# Altium schematic sheet Drone BLDC CNTR V2.SchDoc
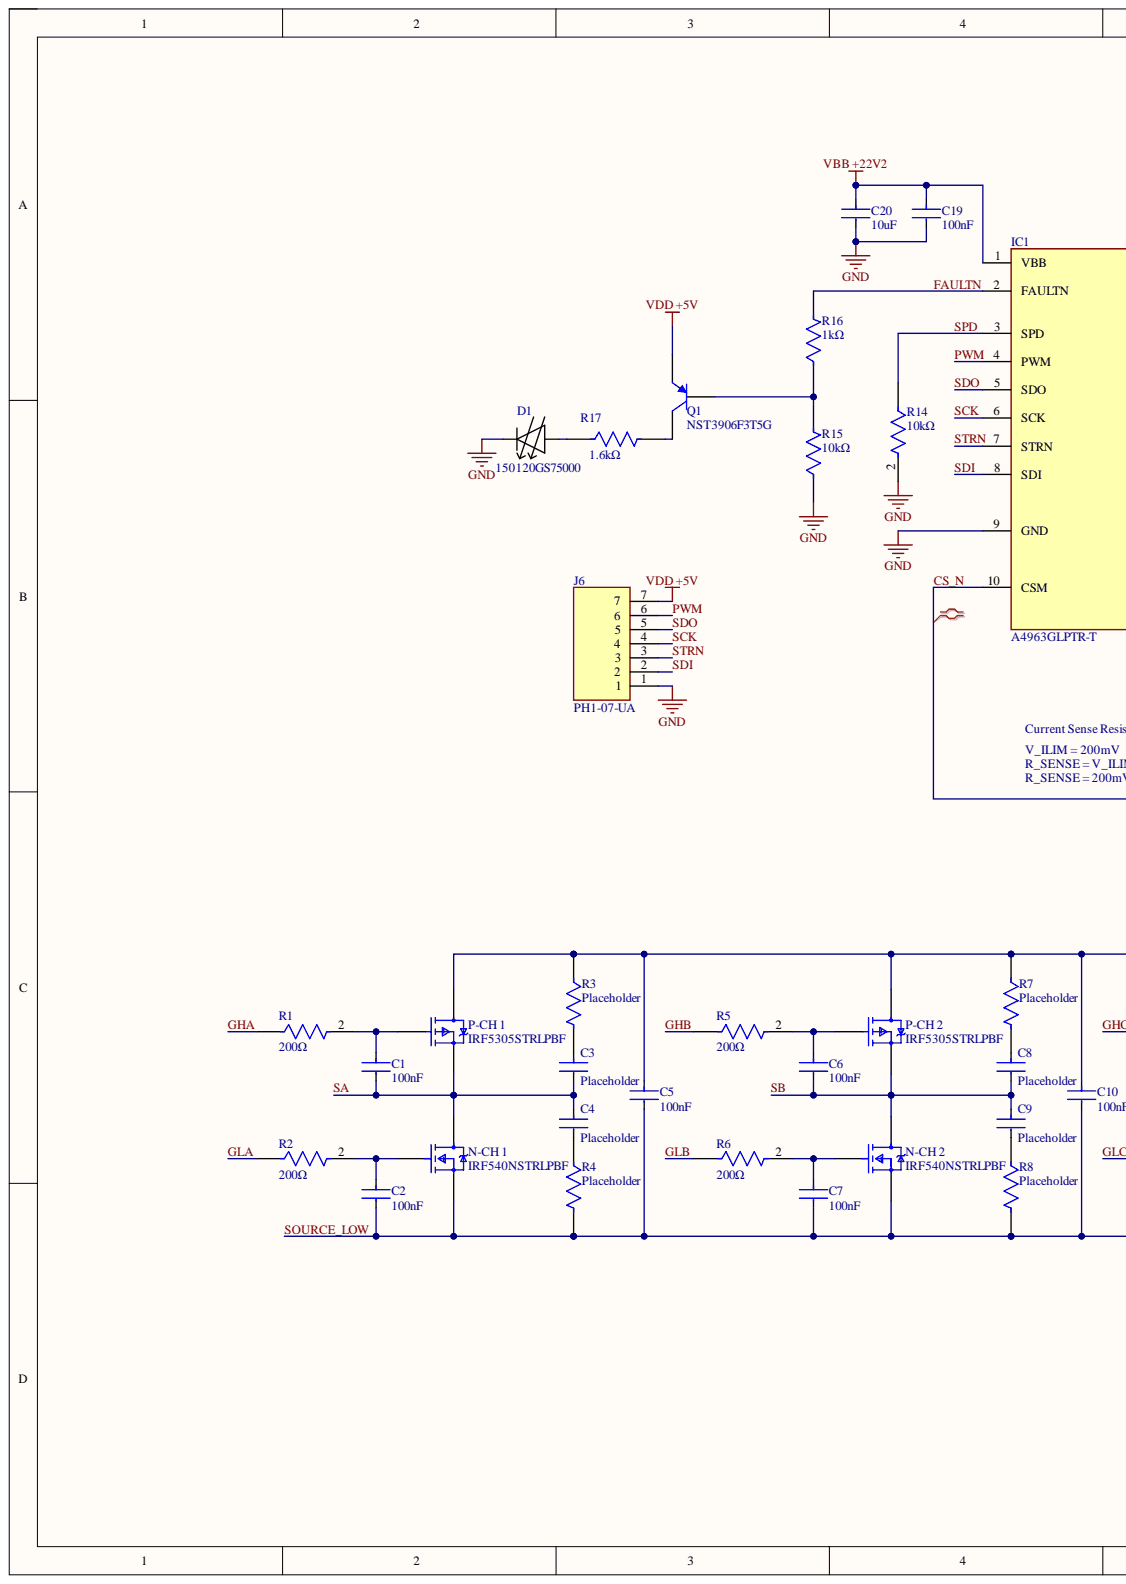
[diagram p0: Drone BLDC CNTR V2.SchDoc, left]
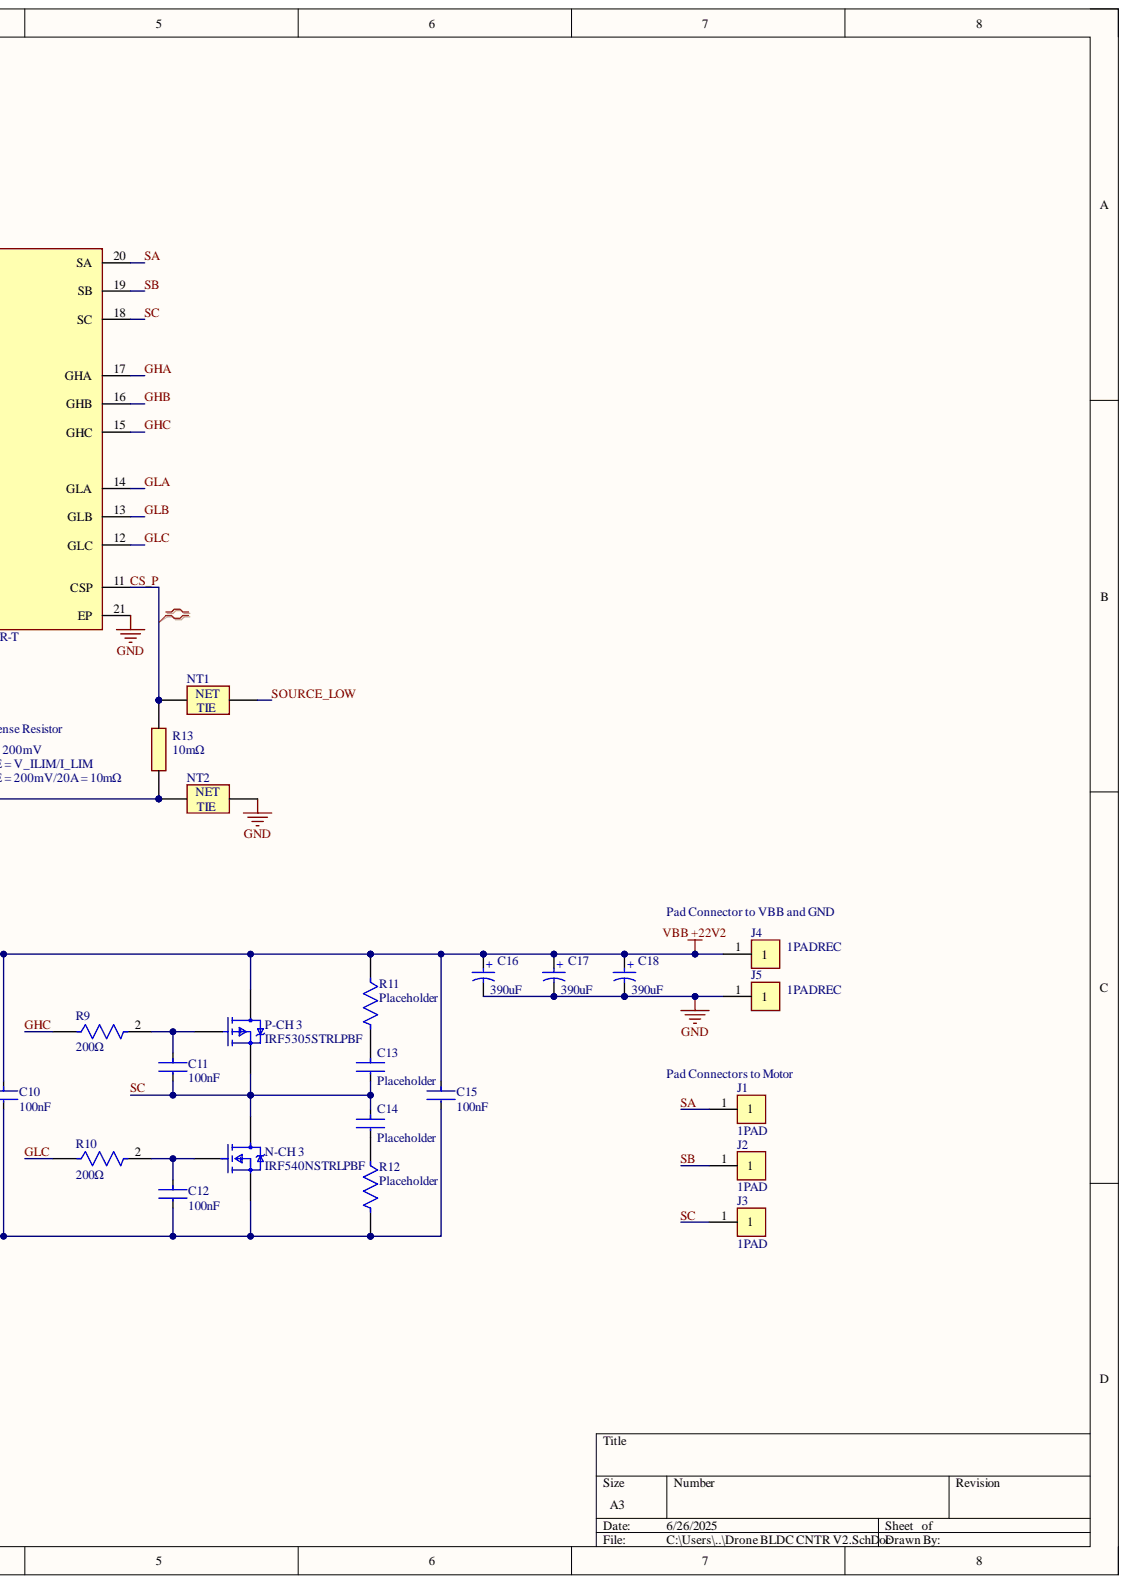
[diagram p1: Drone BLDC CNTR V2.SchDoc, right]

## Parts
C1  100nF  [FP-CL31-IPC_C]
C2  100nF  [FP-CL31-IPC_C]
C3  Placeholder  [FP-CL31-IPC_C]
C4  Placeholder  [FP-CL31-IPC_C]
C5  100nF  [FP-CL31-IPC_C]
C6  100nF  [FP-CL31-IPC_C]
C7  100nF  [FP-CL31-IPC_C]
C8  Placeholder  [FP-CL31-IPC_C]
C9  Placeholder  [FP-CL31-IPC_C]
C10  100nF  [FP-CL31-IPC_C]
C11  100nF  [FP-CL31-IPC_C]
C12  100nF  [FP-CL31-IPC_C]
C13  Placeholder  [FP-CL31-IPC_C]
C14  Placeholder  [FP-CL31-IPC_C]
C15  100nF  [FP-CL31-IPC_C]
C16  390uF  [WCAP-ASLI_D6.3H5.5]
C17  390uF  [WCAP-ASLI_D6.3H5.5]
C18  390uF  [WCAP-ASLI_D6.3H5.5]
C19  100nF  [FP-CL31-IPC_C]
C20  10uF  [FP-CL10-IPC_C]
D1  150120GS75000  [150120GS75000]
IC1  A4963GLPTR-T  [A4405KLPTRT]
J1  1PAD  [1PAD]
J2  1PAD  [1PAD]
J3  1PAD  [1PAD]
J4  1PADREC  [1PADREC]
J5  1PADREC  [1PADREC]
J6  PH1-07-UA  [HDRV7W64P0X254_1X7_1778X250X850P]
N-CH_1  IRF540NSTRLPBF  [FP-PG-TO263-3-MFG]
N-CH_2  IRF540NSTRLPBF  [FP-PG-TO263-3-MFG]
N-CH_3  IRF540NSTRLPBF  [FP-PG-TO263-3-MFG]
NT1  NET_TIE  [NET_TIE]  (value not drawn)
NT2  NET_TIE  [NET_TIE]  (value not drawn)
P-CH_1  IRF5305STRLPBF  [FP-PG-TO263-3-MFG]
P-CH_2  IRF5305STRLPBF  [FP-PG-TO263-3-MFG]
P-CH_3  IRF5305STRLPBF  [FP-PG-TO263-3-MFG]
Q1  NST3906F3T5G  [ONSC-SOT-1123-3-524AA_V]
R1  200Ω  [FP-RMCF0805-MFG]
R2  200Ω  [FP-RMCF0805-MFG]
R3  Placeholder  [FP-RMCF0805-MFG]
R4  Placeholder  [FP-RMCF0805-MFG]
R5  200Ω  [FP-RMCF0805-MFG]
R6  200Ω  [FP-RMCF0805-MFG]
R7  Placeholder  [FP-RMCF0805-MFG]
R8  Placeholder  [FP-RMCF0805-MFG]
R9  200Ω  [FP-RMCF0805-MFG]
R10  200Ω  [FP-RMCF0805-MFG]
R11  Placeholder  [FP-RMCF0805-MFG]
R12  Placeholder  [FP-RMCF0805-MFG]
R13  10mΩ  [RESC7250X190N]
R14  10kΩ  [FP-RMCF0805-MFG]
R15  10kΩ  [FP-RMCF0805-MFG]
R16  1kΩ  [FP-RMCF0805-IPC_C]
R17  1.6kΩ  [FP-RMCF0805-IPC_C]

## Nets
GND: C16.2 C17.2 C18.2 C19.2 C20.2 D1.1 IC1.9(GND) IC1.21(EP) J5.1 J6.1 NT2.1 R14.2 R15.1
VBB +22V2: C5.1 C10.1 C15.1 C16.1 C17.1 C18.1 C19.1 C20.1 IC1.1(VBB) J4.1 P-CH_1.4 P-CH_2.4 P-CH_3.4 R3.1 R7.1 R11.1
VDD +5V: J6.7 Q1.2
CS_N: IC1.10(CSM) NT2.2 R13.1
CS_P: IC1.11(CSP) NT1.2 R13.2
FAULTN: IC1.2(FAULTN) R16.2
GHA: IC1.17(GHA) R1.1
GHB: IC1.16(GHB) R5.1
GHC: IC1.15(GHC) R9.1
GLA: IC1.14(GLA) R2.1
GLB: IC1.13(GLB) R6.1
GLC: IC1.12(GLC) R10.1
PWM: IC1.4(PWM) J6.6
SA: C1.2 C3.2 C4.1 IC1.20(SA) J1.1 N-CH_1.4 P-CH_1.3
SB: C6.2 C8.2 C9.1 IC1.19(SB) J2.1 N-CH_2.4 P-CH_2.3
SC: C11.2 C13.2 C14.1 IC1.18(SC) J3.1 N-CH_3.4 P-CH_3.3
SCK: IC1.6(SCK) J6.4
SDI: IC1.8(SDI) J6.2
SDO: IC1.5(SDO) J6.5
SOURCE_LOW: C2.2 C5.2 C7.2 C10.2 C12.2 C15.2 N-CH_1.3 N-CH_2.3 N-CH_3.3 NT1.1 R4.2 R8.2 R12.2
SPD: IC1.3(SPD) R14.1
STRN: IC1.7(STRN) J6.3
NetC1_1: C1.1 P-CH_1.1 R1.2
NetC2_1: C2.1 N-CH_1.1 R2.2
NetC3_1: C3.1 R3.2
NetC4_2: C4.2 R4.1
NetC6_1: C6.1 P-CH_2.1 R5.2
NetC7_1: C7.1 N-CH_2.1 R6.2
NetC8_1: C8.1 R7.2
NetC9_2: C9.2 R8.1
NetC11_1: C11.1 P-CH_3.1 R9.2
NetC12_1: C12.1 N-CH_3.1 R10.2
NetC13_1: C13.1 R11.2
NetC14_2: C14.2 R12.1
NetD1_2: D1.2 R17.1
NetQ1_1: Q1.1 R15.2 R16.1
NetQ1_3: Q1.3 R17.2

## Library data of the parts
C1-C15 C19 = CMP-13271-001731-1: Cap Ceramic 100nF 50V X7R ±10% SMD 1206 +125°C Embossed T/R; Capacitance: 100nF; Case/Package: 1206; Component Kind: Standard; Depth: 1.6mm; Dielectric: X7R; Height: 0.85mm; Lead Free: Lead Free; Length: 3.2mm; Library Name: Altium Content Vault; Max Operating Temperature: 125°C; Min Operating Temperature: -55°C; Mount: Surface Mount; Package Quantity: 15000; Packaging: Tape and Reel; Radiation Hardening: No; Thickness: 0.037inch; Tolerance: 10%; Voltage: 50V; Voltage Rating: 50V; Voltage Rating (DC): 50V; Width: 0.063inch
C16-C18 = CMP-03913-53914574-1: 865080343009 WCAP-ASLI Aluminum Electrolytic Capacitors; Capacitance: 100µF; ESR (Equivalent Series Resistance): 399.422mΩ; Impedance: 520mΩ; Insulation Resistance: 1MΩ; Leakage Current: 16µA; Life (Hours): 2000hours; Polarity: Polar; Ripple Current: 255mA; Tolerance: 20%; Voltage Rating: 16V; Case/Package: Radial; Component Kind: Standard; Diameter: 6.3mm; Height: 5.5mm; Height - Seated (Max): 5.5118mm; Lead Free: Lead Free; Length: 5.5mm; Library Name: Altium Content Vault; Max Operating Temperature: 105°C; Min Operating Temperature: -55°C; Mount: Surface Mount; NexarPartId: 53914574; Packaging: Tape & Reel (TR); REACH SVHC: Unknown; Ripple Current (AC): 255mA; RoHS: Compliant; Voltage Rating (DC): 16V; Width: 6.6mm
C20 = CMP-13271-000193-1: Cap Ceramic 10nF 50V X7R ±20% Pad SMD 0603 +125°C T/R; Capacitance: 10nF; Case Code (Imperial): 0603; Case Code (Metric): 1608; Case/Package: 0603; Component Kind: Standard; Depth: 0.8mm; Dielectric: X7R; Height: 0.8mm; Lead Free: Lead Free; Length: 1.6mm; Library Name: Altium Content Vault; Max Operating Temperature: 125°C; Min Operating Temperature: -55°C; Mount: Surface Mount; Package Quantity: 4000; Packaging: Tape and Reel; Radiation Hardening: No; Thickness: 0.035inch; Tolerance: 20%; Voltage Rating: 50V; Voltage Rating (DC): 50V; Width: 0.031inch
D1 = CMP-036-000001-1: Green Status LED 1206; Component Kind: Standard; ComponentLink1Description: Schematic; ComponentLink1URL: https://katalog.we-online.de/led/datasheet/150120GS75000.pdf; Design Item ID: 150120GS75000; Source: LED - Chip.SchLib; Library Name: CPRT Workspace
IC1 = A4963GLPTR-T: Integrated Circuit; Manufacturer_Name: Allegro Microsystems; Arrow Part Number: A4963GLPTR-T; Arrow Price/Stock: https://www.arrow.com/en/products/a4963glptr-t/allegro-microsystems?region=nac; Component Kind: Standard; Datasheet Link: https://www.allegromicro.com/~/media/Files/Datasheets/A4963-Datasheet.ashx; Height: 1.2mm; Library Name: SamacSys.SchLib; Manufacturer_Part_Number: A4963GLPTR-T; Mouser Part Number: 250-A4963GLPTR-T; Mouser Price/Stock: https://www.mouser.co.uk/ProductDetail/Allegro-MicroSystems/A4963GLPTR-T?qs=pUKx8fyJudBsbRJaBUG8MA%3D%3D
J1-J3 = 1PAD: 1 Pad Connector; Component Kind: Standard; Library Name: CONNECTORS.SchLib
J4 J5 = 1PADREC: 1 Pad Rectangular Connector; Component Kind: Standard; Library Name: CONNECTORS.SchLib
J6 = PH1-07-UA: Connector; Manufacturer_Name: Adam Tech; Arrow Part Number: PH1-07-UA; Arrow Price/Stock: https://www.arrow.com/en/products/ph1-07-ua/adam-tech?utm_currency=USD&region=nac; Component Kind: Standard; Datasheet Link: https://app.adam-tech.com/products/download/data_sheet/201605/ph1-xx-ua-data-sheet.pdf; Height: 8.5mm; Library Name: CONNECTORS.SchLib; Manufacturer_Part_Number: PH1-07-UA; Mouser Part Number: 737-PH1-07-UA; Mouser Price/Stock: https://www.mouser.co.uk/ProductDetail/Adam-Tech/PH1-07-UA?qs=HoCaDK9Nz5eVL%252BujfwHkAw%3D%3D
N-CH_1-N-CH_3 = CMP-00196-65873407-1: IRF540NSTRLPBF Transistor MOSFET N Channel 100 Volt 33 Amp 3-Pin 2+ Tab D2pak Tape And Reel; Continuous Drain Current (ID): 33A; Drain to Source Breakdown Voltage: 100V; Drain to Source Resistance: 44mΩ; Element Configuration: Single; Fall Time: 35ns; Gate to Source Voltage (Vgs): 20V; Input Capacitance: 1.96nF; Max Junction Temperature (Tj): 175°C; Max Power Dissipation: 3.8W; Nominal Vgs: 4V; Number of Channels: 1; Rds On Max: 8.4mΩ; Recovery Time: 170ns; Turn-Off Delay Time: 39ns; Turn-On Delay Time: 11ns; Case/Package: D2PAK; Component Kind: Standard; Contact Plating: Tin; Current Rating: 33A; Drain to Source Voltage (Vdss): 100V; Dual Supply Voltage: 100V; Height: 4.826mm; Lead Free: Lead Free; Length: 10.668mm; Library Name: Altium Content Vault; Lifecycle Status: NRND; Max Operating Temperature: 175°C; Min Operating Temperature: -55°C; Mount: Surface Mount; NexarPartId: 65873407; Number of Elements: 1; Number of Pins: 3; On-State Resistance: 44mΩ; Package Quantity: 800; Packaging: Bulk; Power Dissipation: 3.8W; Radiation Hardening: No; REACH SVHC: No SVHC; Resistance: 44MΩ; Rise Time: 35ns; RoHS: Compliant; Schedule B: 8541290080, 8541290080; Termination: SMD/SMT; Threshold Voltage: 4V; Voltage Rating (DC): 100V; Width: 9.65mm
NT1 NT2 = NET_TIE: Net Tie Pad; Component Kind: Standard; Library Name: CONNECTORS.SchLib
P-CH_1-P-CH_3 = CMP-32901-000146-1: MOSFET P-CH 55V 31A D2PAK; Manufacturer: Infineon Technologies; Continuous Drain Current: -31A; Depletion Mode: False; Drain To Source Breakdown Voltage: -55V; Drain To Source Resistance: 0.06Ω; Gate Charge At Vgs: 63nC @ -10V; Gate To Source Voltage: 20V; Input Capacitace At Vds: 1200pF @ -25V; Max Forward Diode Voltage: -1.3V; Max Junction Temp: +175°C; Number Of Channels: 1; Power Dissipation: 3.8W; Pulse Drain Current: -110A; Reverse Recovery Charge: 170nC; Reverse Recovery Time: 71ns; Rthja Max: 40°C/W; Standoff Height: 0mm; Threshold Vgs Max: -4V; Threshold Vgs Min: -2V; Turn Off Delay Time: 39ns; Turn On Delay Time: 14ns; Automotive: No; Category: Trans; Component Kind: Standard; ComponentLink2Description: Datasheet; ComponentLink2URL: https://www.infineon.com/dgdl/irf5305spbf.pdf?fileId=5546d462533600a4015355e378101995; Device Class L1: Discrete Semiconductors; Device Class L2: Transistors; Device Class L3: MOSFETs; Digikey Description: MOSFET P-CH 55V 31A D2PAK; Drain To Source Voltage: -55V; Height: 5.084mm; Lead Free: Yes; Library Name: Altium Content Vault; Manufacturer Part Number: IRF5305STRLPBF; Number Of P Channels: 1; Octopart: https://octopart.com/irf5305strlpbf-infineon-65873398; Package: PG-TO263-3; RoHS: TRUE; Temperature: -55°C to +175°C; Temperature Range High: +175°C; Temperature Range Low: -55°C
Q1 = CMP-1048-00442-1: PNP General Purpose Transistor, 3-Pin SOT-1123, Pb-Free, Tape and Reel; Manufacturer: On Semiconductor; fT Min (MHz): 250; IC Continuous (A): -0.2; Component Kind: Standard; ComponentLink1Description: Manufacturer URL; ComponentLink1URL: http://www.onsemi.com/; ComponentLink2Description: Datasheet; ComponentLink2URL: http://www.onsemi.com/pub_link/Collateral/NST3906F3-D.PDF; ComponentLink3Description: Package Specification; ComponentLink3URL: http://www.onsemi.cn/pub_link/Collateral/524AA.PDF; DatasheetVersion: Rev. 1; Library Name: Altium Content Vault; Mounting Technology: Surface Mount; PackageDescription: 3-Pin Small Outline Transistor, Body 0.8 x 0.6 mm, Pitch 0.375 mm; PackageReference: SOT-1123-3-524AA; PackageVersion: Rev. C, 11/2011; Packing: Tape and Reel; PartNumber: NST3906F3T5G; Polarity: PNP; RoHS: TRUE
R1-R12 R14 = CMP-26527-000524-1: 200Ω ±1% 0.125W 0805 Thick Film Chip Resistor AEC-Q200 compliant; Max Power Dissipation: 125mW; Resistance: 200R; Temperature Coefficient: 100ppm/°C; Case Code (Imperial): 0805; Case Code (Metric): 2012; Case/Package: 0805; Component Kind: Standard; Construction: Rectangular; Contact Plating: Tin; Depth: 1.25mm; Features: Automotive AEC-Q200; Height: 0.026inch; Lead Free: Lead Free; Length: 0.079inch; Library Name: Altium Content Vault; Max Operating Temperature: 155°C; Military Standard: Not; Min Operating Temperature: -55°C; Mount: Surface Mount; Number of Terminations: 2; Packaging: Tape and Reel; Power Rating: 125mW; Radiation Hardening: No; Resistor Type: Thick Film; RoHS Compliant: Yes; Series: RMCF; Size Code: 0805; Tolerance: 1%; Width: 0.049inch
R13 = HCSM2818FT10L0: 10mΩ 5W Resistor; Manufacturer_Name: Stackpole Electronics; Arrow Part Number: HCSM2818FT10L0; Arrow Price/Stock: https://www.arrow.com/en/products/hcsm2818ft10l0/stackpole-electronics; Component Kind: Standard; Datasheet Link: https://cs.seielect.com/catalog/SEI-HCSM.pdf; Height: 1.9mm; Library Name: HCSM2818FT10L0.SchLib; Manufacturer_Part_Number: HCSM2818FT10L0
R15 = CMP-26527-000121-1: RES 10K OHM 1% 1/8W 0805; Max Power Dissipation: 125mW; Resistance: 10kR; Temperature Coefficient: 100ppm/°C; Case Code (Imperial): 0805; Case Code (Metric): 2012; Case/Package: 0805; Component Kind: Standard; Construction: Rectangular; Contact Plating: Tin; Depth: 1.25mm; Features: Automotive AEC-Q200; Height: 0.026inch; Lead Free: Lead Free; Length: 2mm; Library Name: Altium Content Vault; Max Operating Temperature: 155°C; Military Standard: Not; Min Operating Temperature: -55°C; Mount: Surface Mount; Number of Terminations: 2; Packaging: Tape and Reel; Power Rating: 125mW; Radiation Hardening: No; Resistor Type: Thick Film; RoHS Compliant: Yes; Series: RMCF; Size Code: 0805; Tolerance: 1%; Width: 0.049inch
R16 = CMP-26527-002331-1: 1kΩ ±1% 0.125W 0805 Thick Film Chip Resistor AEC-Q200 compliant; Max Power Dissipation: 125mW; Resistance: 1kR; Temperature Coefficient: 100ppm/°C; Case Code (Imperial): 0805; Case Code (Metric): 2012; Case/Package: 0805; Component Kind: Standard; Construction: Rectangular; Contact Plating: Tin; Depth: 1.25mm; Features: Automotive AEC-Q200; Height: 0.026inch; Lead Free: Lead Free; Length: 2mm; Library Name: Altium Content Vault; Max Operating Temperature: 155°C; Military Standard: Not; Min Operating Temperature: -55°C; Mount: Surface Mount; Number of Terminations: 2; Packaging: Tape and Reel; Power Rating: 125mW; Radiation Hardening: No; Resistor Type: Thick Film; RoHS Compliant: Yes; Series: RMCF; Size Code: 0805; Tolerance: 1%; Width: 0.049inch
R17 = CMP-26527-000088-1: 2.2kΩ ±5% 0.125W 0805 Thick Film Chip Resistor AEC-Q200 compliant; Max Power Dissipation: 125mW; Resistance: 2.2kR; Temperature Coefficient: 100ppm/°C; Case Code (Imperial): 0805; Case Code (Metric): 2012; Case/Package: 0805; Component Kind: Standard; Construction: Rectangular; Contact Plating: Tin; Depth: 1.25mm; Features: Automotive AEC-Q200; Height: 0.026inch; Lead Free: Lead Free; Length: 2mm; Library Name: Altium Content Vault; Max Operating Temperature: 155°C; Military Standard: Not; Min Operating Temperature: -55°C; Mount: Surface Mount; Number of Terminations: 2; Packaging: Tape and Reel; Power Rating: 125mW; Radiation Hardening: No; Resistor Type: Thick Film; RoHS Compliant: Yes; Series: RMCF; Size Code: 0805; Tolerance: 5%; Width: 0.049inch
